# Altium schematic sheet raumluftsensor.SchDoc(raumluftsensor)
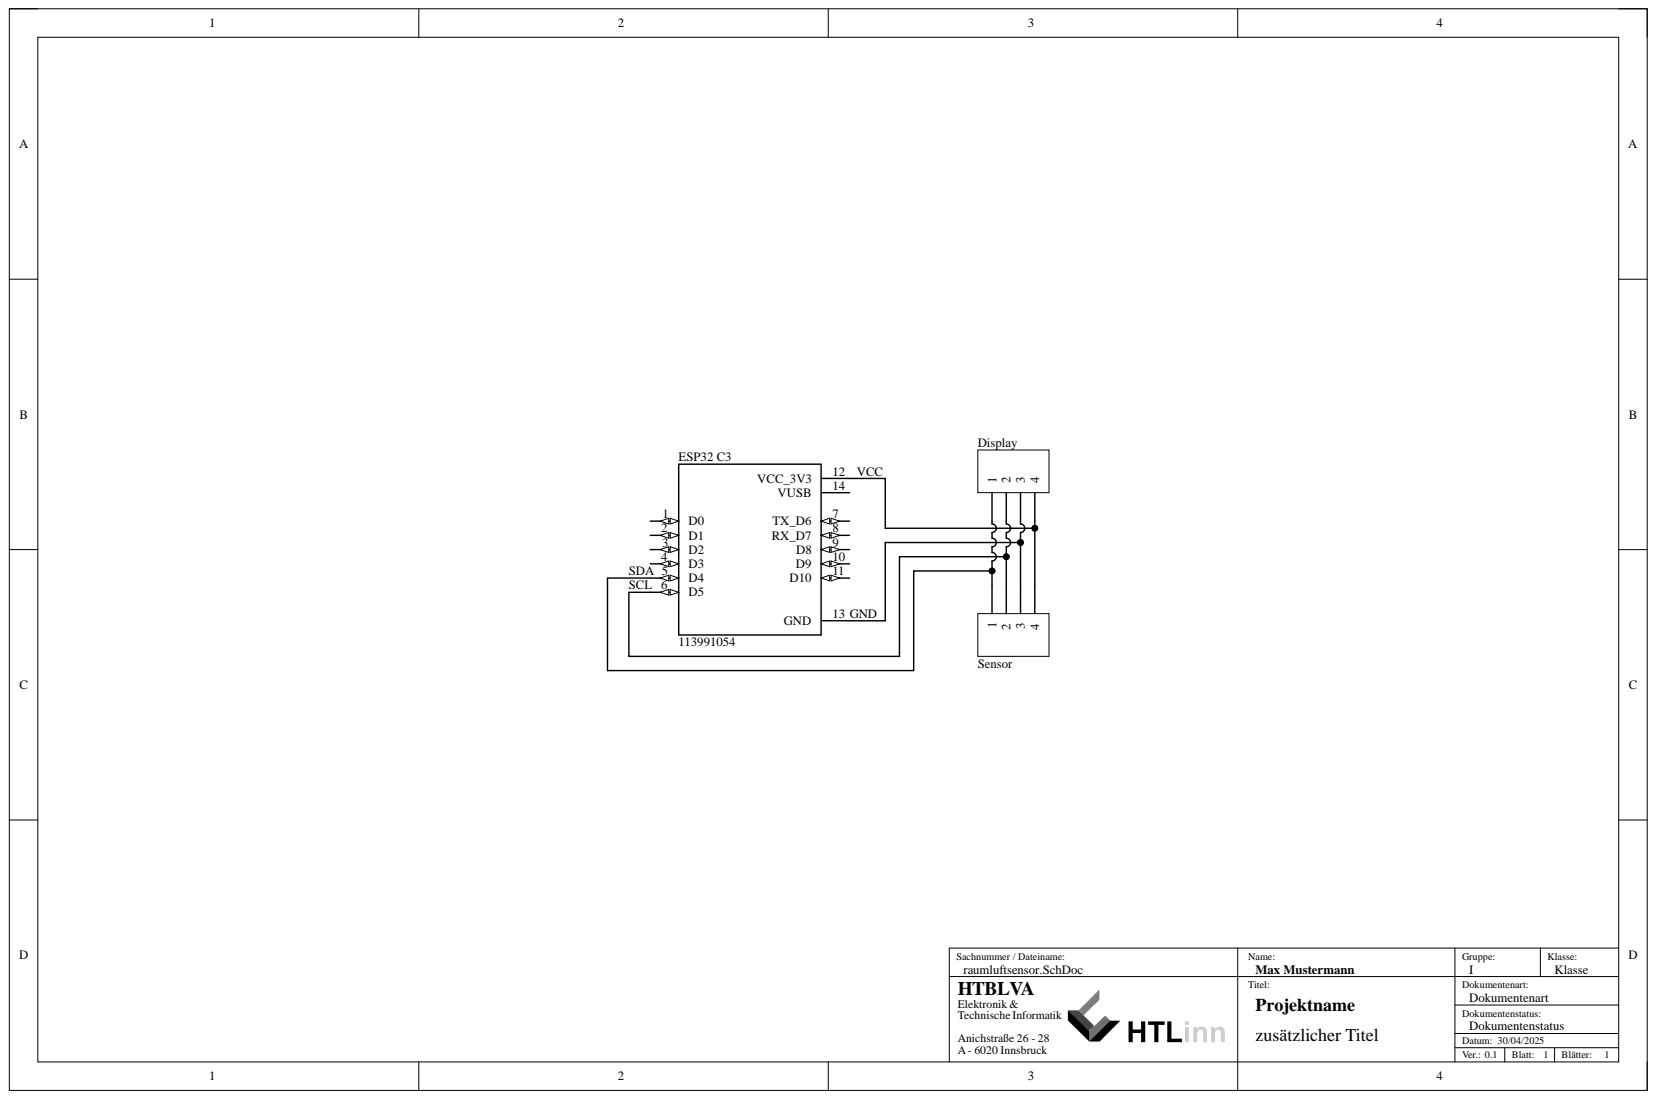
[diagram p0: raumluftsensor.SchDoc]

## Parts
Display  Header 4  [HDR1X4]  (value not drawn)
ESP32_C3  113991054  [MODULE_113991054]
Sensor  Header 4  [HDR1X4]  (value not drawn)

## Nets
GND: Display.3 ESP32_C3.13(GND) Sensor.3
SCL: Display.2 ESP32_C3.6(D5) Sensor.2
SDA: Display.1 ESP32_C3.5(D4) Sensor.1
VCC: Display.4 ESP32_C3.12(VCC_3V3) Sensor.4

Not connected: ESP32_C3 1(D0) 2(D1) 3(D2) 4(D3) 7(TX_D6) 8(RX_D7) 9(D8) 10(D9) 11(D10) 14(VUSB)

## Library data of the parts
Display Sensor = Header 4: Header, 4-Pin; Component Kind: Standard; LatestRevisionDate: 17-Jul-2002; LatestRevisionNote: Re-released for DXP Platform.; Library Name: Miscellaneous Connectors.IntLib; Signal Integrity: Connector; Publisher: Altium Limited
ESP32_C3 = 113991054: - ESP32-C3 Transceiver; 802.11 a/b/g/n (Wi-Fi, WiFi, WLAN), BluetoothÂ® Smart 4.x Low Energy (BLE) 2.4GHz Evaluation Board; MF: Seeed Technology Co., Ltd; Check_prices: https://www.snapeda.com/parts/113991054/Seeed+Studio/view-part/?ref=eda; Component Kind: Standard; Library Name: ESP32C3.IntLib; MP: 113991054; Package: None; SnapEDA_Link: https://www.snapeda.com/parts/113991054/Seeed+Studio/view-part/?ref=snap
